# Altium schematic sheet Arithmatic unit.SchDoc
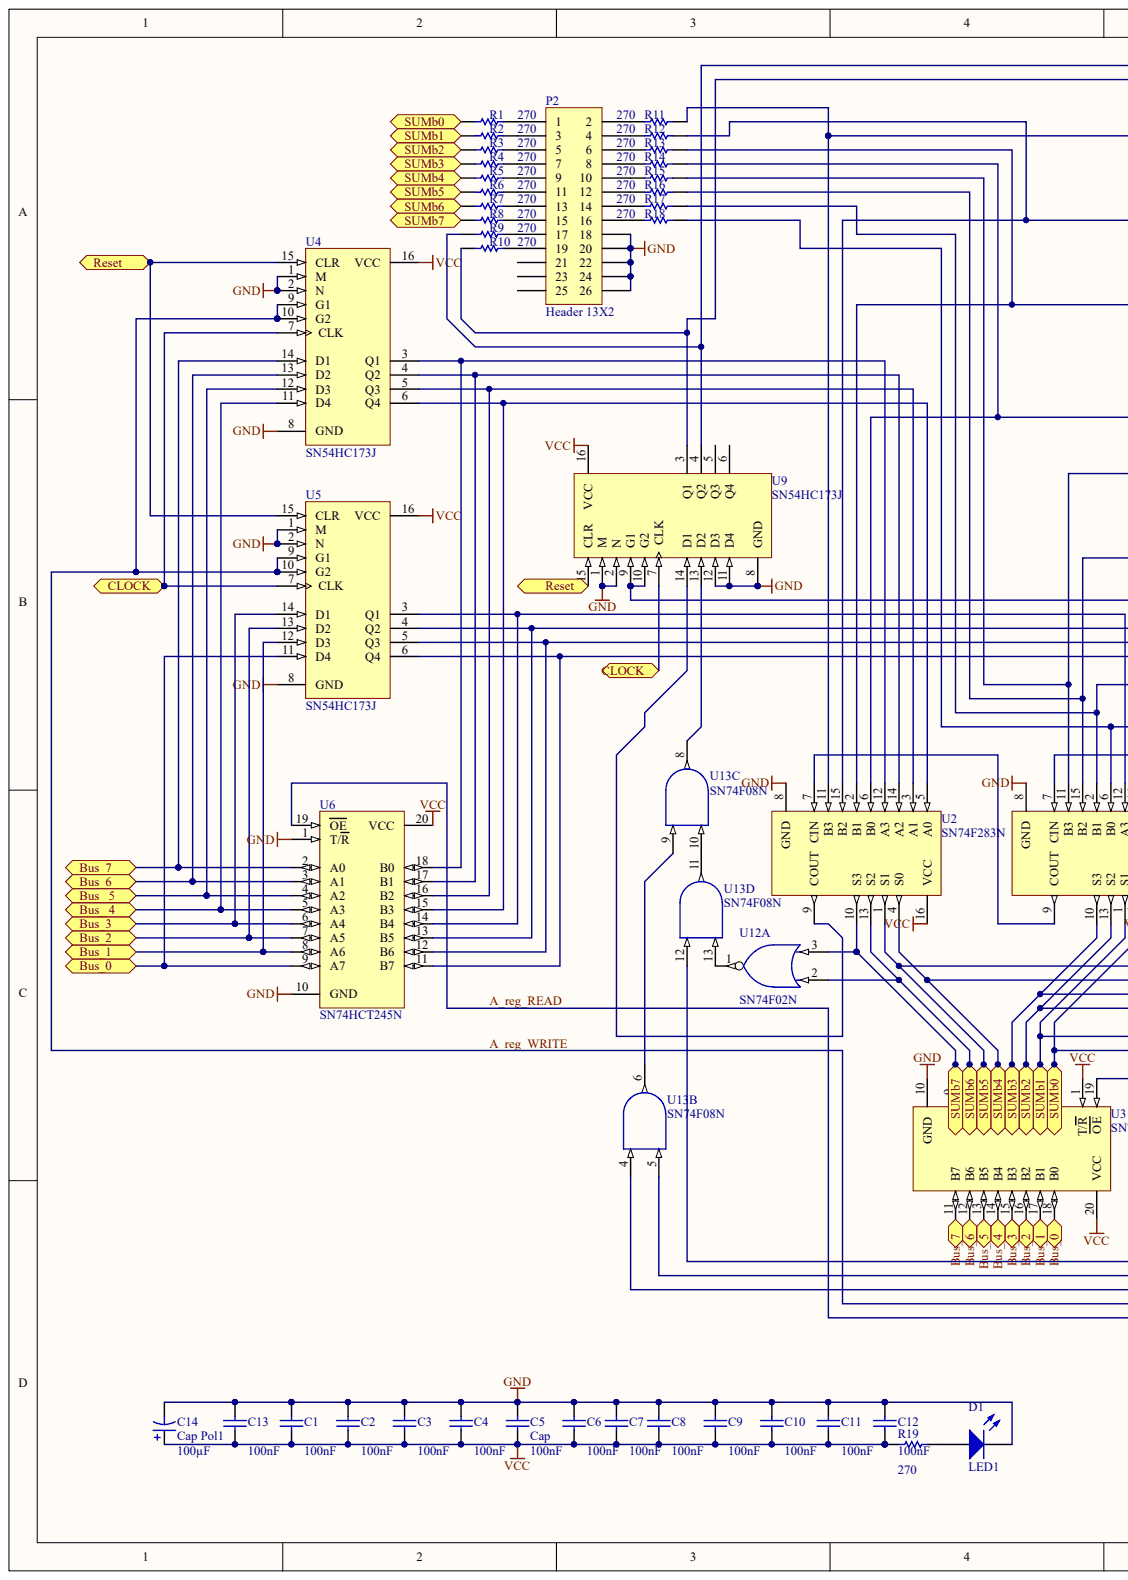
[diagram p0: Arithmatic unit.SchDoc, left]
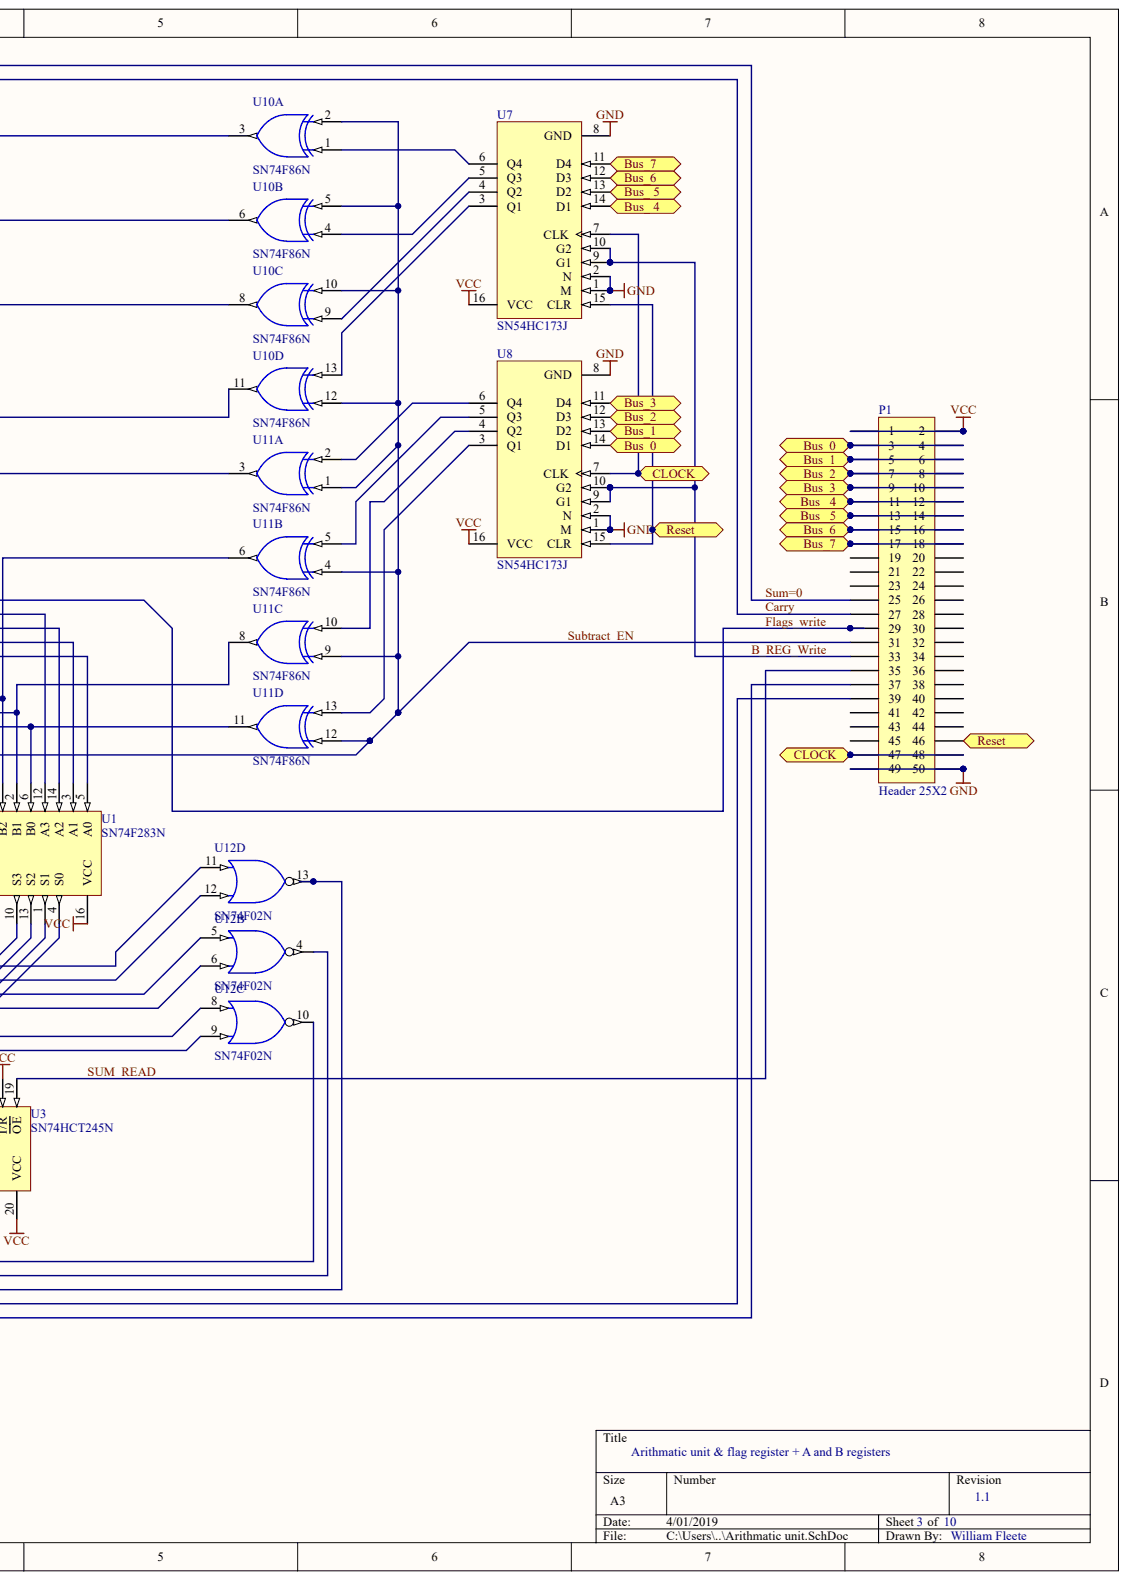
[diagram p1: Arithmatic unit.SchDoc, right]

## Parts
C1  value 100nF  (hidden comment: Cap)
C2  value 100nF  (hidden comment: Cap)
C3  value 100nF  (hidden comment: Cap)
C4  value 100nF  (hidden comment: Cap)
C5  Cap  value 100nF
C6  Cap  value 100nF
C7  value 100nF  (hidden comment: Cap)
C8  value 100nF  (hidden comment: Cap)
C9  value 100nF  (hidden comment: Cap)
C10  value 100nF  (hidden comment: Cap)
C11  value 100nF  (hidden comment: Cap)
C12  value 100nF  (hidden comment: Cap)
C13  Cap  value 100nF
C14  Cap Pol1  value 100µF
D1  LED1
P1  Header 25X2
P2  Header 13X2
R1  value 270  (hidden comment: Res1)
R2  value 270  (hidden comment: Res1)
R3  value 270  (hidden comment: Res1)
R4  value 270  (hidden comment: Res1)
R5  value 270  (hidden comment: Res1)
R6  value 270  (hidden comment: Res1)
R7  value 270  (hidden comment: Res1)
R8  value 270  (hidden comment: Res1)
R9  value 270  (hidden comment: Res1)
R10  value 270  (hidden comment: Res1)
R11  value 270  (hidden comment: Res1)
R12  value 270  (hidden comment: Res1)
R13  value 270  (hidden comment: Res1)
R14  value 270  (hidden comment: Res1)
R15  value 270  (hidden comment: Res1)
R16  value 270  (hidden comment: Res1)
R17  value 270  (hidden comment: Res1)
R18  value 270  (hidden comment: Res1)
R19  value 270  (hidden comment: Res1)
U1  SN74F283N
U2  SN74F283N
U3  SN74HCT245N
U4  SN54HC173J
U5  SN54HC173J
U6  SN74HCT245N
U7  SN54HC173J
U8  SN54HC173J
U9  SN54HC173J
U10  SN74F86N  (parts A,B,C,D)
U11  SN74F86N  (parts A,B,C,D)
U12  SN74F02N  (parts A,B,C,D)
U13  SN74F08N  (parts B,C,D)

## Ports
BUS_0, BUS_1, BUS_2, BUS_3, BUS_6, BUS_7, BUS_ 4, BUS_ 5, CLOCK, RESET, SUMB0, SUMB1, SUMB2, SUMB3, SUMB4, SUMB5, SUMB6, SUMB7

## Nets
GND: C1.2 C2.2 C3.2 C4.2 C5.2 C6.2 C7.2 C8.2 C9.2 C10.2 C11.2 C12.2 C13.2 C14.2 D1.2 P1.49 P1.50 P2.18 P2.20 P2.22 P2.24 P2.26 U1.8(GND) U2.8(GND) U3.10(GND) U4.1(M) U4.2(N) U4.8(GND) U5.1(M) U5.2(N) U5.8(GND) U6.1(T/R) U6.10(GND) U7.1(M) U7.2(N) U7.8(GND) U8.1(M) U8.2(N) U8.8(GND) U9.1(M) U9.2(N) U9.8(GND) U9.11(D4) U9.12(D3) U10.7 U11.7 U12.7 U13.7
VCC: C1.1 C2.1 C3.1 C4.1 C5.1 C6.1 C7.1 C8.1 C9.1 C10.1 C11.1 C12.1 C13.1 C14.1 P1.1 P1.2 R19.1 U1.16(VCC) U2.16(VCC) U3.1(T/R) U3.20(VCC) U4.16(VCC) U5.16(VCC) U6.20(VCC) U7.16(VCC) U8.16(VCC) U9.16(VCC) U10.14 U11.14 U12.14 U13.14
A_REG_READ: P1.37 U6.19(OE)
A_REG_WRITE: P1.39 U4.9(G1) U4.10(G2) U5.9(G1) U5.10(G2)
B_REG_WRITE: P1.33 U7.9(G1) U7.10(G2) U8.9(G1) U8.10(G2)
CARRY: P1.27 R10.1 U9.3(Q1)
CLOCK: P1.47 P1.48 U4.7(CLK) U5.7(CLK) U7.7(CLK) U8.7(CLK) U9.7(CLK) ; port CLOCK
FLAGS_WRITE: P1.29 U9.9(G1) U9.10(G2)
SUBTRACT_EN: P1.31 U1.7(CIN) U10.2 U10.5 U10.10 U10.12 U11.1 U11.4 U11.9 U11.12
SUM=0: P1.25 R9.1 U9.4(Q2)
SUM_READ: P1.35 U3.19(OE)
NetD1_1: D1.1 R19.2
NetP1_3: P1.3 P1.4 U3.18(B0) U5.11(D4) U6.9(A7) U8.14(D1) ; port BUS_0
NetP1_5: P1.5 P1.6 U3.17(B1) U5.12(D3) U6.8(A6) U8.13(D2) ; port BUS_1
NetP1_7: P1.7 P1.8 U3.16(B2) U5.13(D2) U6.7(A5) U8.12(D3) ; port BUS_2
NetP1_9: P1.9 P1.10 U3.15(B3) U5.14(D1) U6.6(A4) U8.11(D4) ; port BUS_3
NetP1_11: P1.11 P1.12 U3.14(B4) U4.11(D4) U6.5(A3) U7.14(D1) ; port BUS_ 4
NetP1_13: P1.13 P1.14 U3.13(B5) U4.12(D3) U6.4(A2) U7.13(D2) ; port BUS_ 5
NetP1_15: P1.15 P1.16 U3.12(B6) U4.13(D2) U6.3(A1) U7.12(D3) ; port BUS_6
NetP1_17: P1.17 P1.18 U3.11(B7) U4.14(D1) U6.2(A0) U7.11(D4) ; port BUS_7
NetP1_46: P1.46 U4.15(CLR) U5.15(CLR) U7.15(CLR) U8.15(CLR) U9.15(CLR) ; port RESET
NetP2_1: P2.1 R1.2
NetP2_2: P2.2 R11.1
NetP2_3: P2.3 R2.2
NetP2_4: P2.4 R12.1
NetP2_5: P2.5 R3.2
NetP2_6: P2.6 R13.1
NetP2_7: P2.7 R4.2
NetP2_8: P2.8 R14.1
NetP2_9: P2.9 R5.2
NetP2_10: P2.10 R15.1
NetP2_11: P2.11 R6.2
NetP2_12: P2.12 R16.1
NetP2_13: P2.13 R7.2
NetP2_14: P2.14 R17.1
NetP2_15: P2.15 R8.2
NetP2_16: P2.16 R18.1
NetP2_17: P2.17 R9.2
NetP2_19: P2.19 R10.2
NetR1_1: R1.1 U1.4(S0) U3.2(A0) U12.9 ; port SUMB0
NetR2_1: R2.1 U1.1(S1) U3.3(A1) U12.8 ; port SUMB1
NetR3_1: R3.1 U1.13(S2) U3.4(A2) U12.6 ; port SUMB2
NetR4_1: R4.1 U1.10(S3) U3.5(A3) U12.5 ; port SUMB3
NetR5_1: R5.1 U2.4(S0) U3.6(A4) U12.12 ; port SUMB4
NetR6_1: R6.1 U2.1(S1) U3.7(A5) U12.11 ; port SUMB5
NetR7_1: R7.1 U2.13(S2) U3.8(A6) U12.2 ; port SUMB6
NetR8_1: R8.1 U2.10(S3) U3.9(A7) U12.3 ; port SUMB7
NetR11_2: R11.2 U2.11(B3) U10.3
NetR12_2: R12.2 U2.15(B2) U10.6
NetR13_2: R13.2 U2.2(B1) U10.8
NetR14_2: R14.2 U2.6(B0) U10.11
NetR15_2: R15.2 U1.11(B3) U11.3
NetR16_2: R16.2 U1.15(B2) U11.6
NetR17_2: R17.2 U1.2(B1) U11.8
NetR18_2: R18.2 U1.6(B0) U11.11
NetU1_3: U1.3(A1) U5.5(Q3) U6.12(B6)
NetU1_5: U1.5(A0) U5.6(Q4) U6.11(B7)
NetU1_9: U1.9(COUT) U2.7(CIN)
NetU1_12: U1.12(A3) U5.3(Q1) U6.14(B4)
NetU1_14: U1.14(A2) U5.4(Q2) U6.13(B5)
NetU2_3: U2.3(A1) U4.5(Q3) U6.16(B2)
NetU2_5: U2.5(A0) U4.6(Q4) U6.15(B3)
NetU2_9: U2.9(COUT) U9.14(D1)
NetU2_12: U2.12(A3) U4.3(Q1) U6.18(B0)
NetU2_14: U2.14(A2) U4.4(Q2) U6.17(B1)
NetU7_3: U7.3(Q1) U10.13
NetU7_4: U7.4(Q2) U10.9
NetU7_5: U7.5(Q3) U10.4
NetU7_6: U7.6(Q4) U10.1
NetU8_3: U8.3(Q1) U11.13
NetU8_4: U8.4(Q2) U11.10
NetU8_5: U8.5(Q3) U11.5
NetU8_6: U8.6(Q4) U11.2
NetU9_13: U9.13(D2) U13.8
NetU12_1: U12.1 U13.13
NetU12_4: U12.4 U13.5
NetU12_10: U12.10 U13.12
NetU12_13: U12.13 U13.4
NetU13_6: U13.6 U13.9
NetU13_10: U13.10 U13.11

Not connected: P1 19 20 21 22 23 24 26 28 30 32 34 36 38 40 41 42 43 44 45; P2 21 23 25; U9 5(Q3) 6(Q4)

## Library data of the parts
C1-C14 D1 P1 P2 R1-R19 U1-U13 = (no symbol name): Publisher: Altium Limited
  C1-C13: Published: 8-Jun-2000; LatestRevisionDate: 17-Jul-2002; LatestRevisionNote: Re-released for DXP Platform.; PackageReference: RAD-0.3; PackageDescription: Radial Cap, Thru-Hole; 2 Leads; 0.3 in Pin Spacing
  C14: Published: 8-Jun-2000; LatestRevisionDate: 17-Jul-2002; LatestRevisionNote: Re-released for DXP Platform.; PackageReference: RB7.6-15; PackageDescription: Polarized Capacitor (Radial); 2 Leads
  D1: Published: 8-Jun-2000; LatestRevisionDate: 08-Jul-2005; LatestRevisionNote: Mech Layer 1 removed.; PackageReference: LED-1; PackageDescription: LED; 2 Leads
  P1 P2: LatestRevisionDate: 17-Jul-2002; LatestRevisionNote: Re-released for DXP Platform.
  R1-R19: Published: 8-Jun-2000; LatestRevisionDate: 17-Jul-2002; LatestRevisionNote: Re-released for DXP Platform.; PackageReference: AXIAL-0.3; PackageDescription: Axial Device, Thru-Hole; 2 Leads; 0.3 in Pin Spacing
  U1 U2: Published: 8-Jun-2000; LatestRevisionDate: 04-Mar-2005; LatestRevisionNote: Stylized 3D Model Added.; DatasheetVersion: Oct-1993; PackageVersion: Oct-1995; PackageReference: N016; PackageDescription: DIP; 16 Leads; Row Spacing 7.62 mm; Pitch 2.54 mm; Code_JEDEC: MS-001-BB; ComponentLink1Description: Manufacturer Link; ComponentLink1URL: http://www.ti.com; ComponentLink2Description: Datasheet; ComponentLink2URL: http://www-s.ti.com/sc/ds/sn74f283.pdf
  U3 U6: Published: 8-Jun-2000; LatestRevisionDate: 04-Mar-2005; LatestRevisionNote: Stylized 3D Model Added.; DatasheetVersion: May-1997; PackageVersion: Oct-1995; PackageReference: N020; PackageDescription: DIP; 20 Leads; Row Spacing 7.62 mm; Pitch 2.54 mm; ComponentLink1Description: Manufacturer Link; ComponentLink1URL: http://www.ti.com/; ComponentLink2Description: Datasheet; ComponentLink2URL: http://www-s.ti.com/sc/ds/sn74hct245.pdf
  U4 U5 U7-U9: Published: 8-Jun-2000; LatestRevisionDate: 04-Mar-2005; LatestRevisionNote: Stylized 3D Model Added.; DatasheetVersion: Sep-1987; PackageVersion: Jun-1999; PackageReference: J016D; PackageDescription: DIP; 16 Leads; Row Spacing 7.62 mm; Pitch 2.54 mm; ComponentLink1Description: Manufacturer Link; ComponentLink1URL: http://www.ti.com/
  U10 U11: Published: 8-Jun-2000; LatestRevisionDate: 04-Mar-2005; LatestRevisionNote: Stylized 3D Model Added.; DatasheetVersion: Jan-1997; PackageVersion: Oct-1995; PackageReference: N014; PackageDescription: DIP; 14 Leads; Row Spacing 7.62 mm; Pitch 2.54 mm; ComponentLink1Description: Manufacturer Link; ComponentLink1URL: http://www.ti.com/; ComponentLink2Description: Datasheet; ComponentLink2URL: http://www-s.ti.com/sc/ds/sn74f86.pdf
  U12: Published: 8-Jun-2000; LatestRevisionDate: 04-Mar-2005; LatestRevisionNote: Stylized 3D Model Added.; DatasheetVersion: Oct-1993; PackageVersion: Oct-1995; PackageReference: N014; PackageDescription: DIP; 14 Leads; Row Spacing 7.62 mm; Pitch 2.54 mm; ComponentLink1Description: Manufacturer Link; ComponentLink1URL: http://www.ti.com/; ComponentLink2Description: Datasheet; ComponentLink2URL: http://www-s.ti.com/sc/ds/SN54F02.pdf
  U13: Published: 8-Jun-2000; LatestRevisionDate: 04-Mar-2005; LatestRevisionNote: Stylized 3D Model Added.; DatasheetVersion: Oct-1993; PackageVersion: Oct-1995; PackageReference: N014; PackageDescription: DIP; 14 Leads; Row Spacing 7.62 mm; Pitch 2.54 mm; ComponentLink1Description: Manufacturer Link; ComponentLink1URL: http://www.ti.com/; ComponentLink2Description: Datasheet; ComponentLink2URL: http://www-s.ti.com/sc/ds/SN74F08.pdf
